# Altium schematic sheet D1.SchDoc(D1)
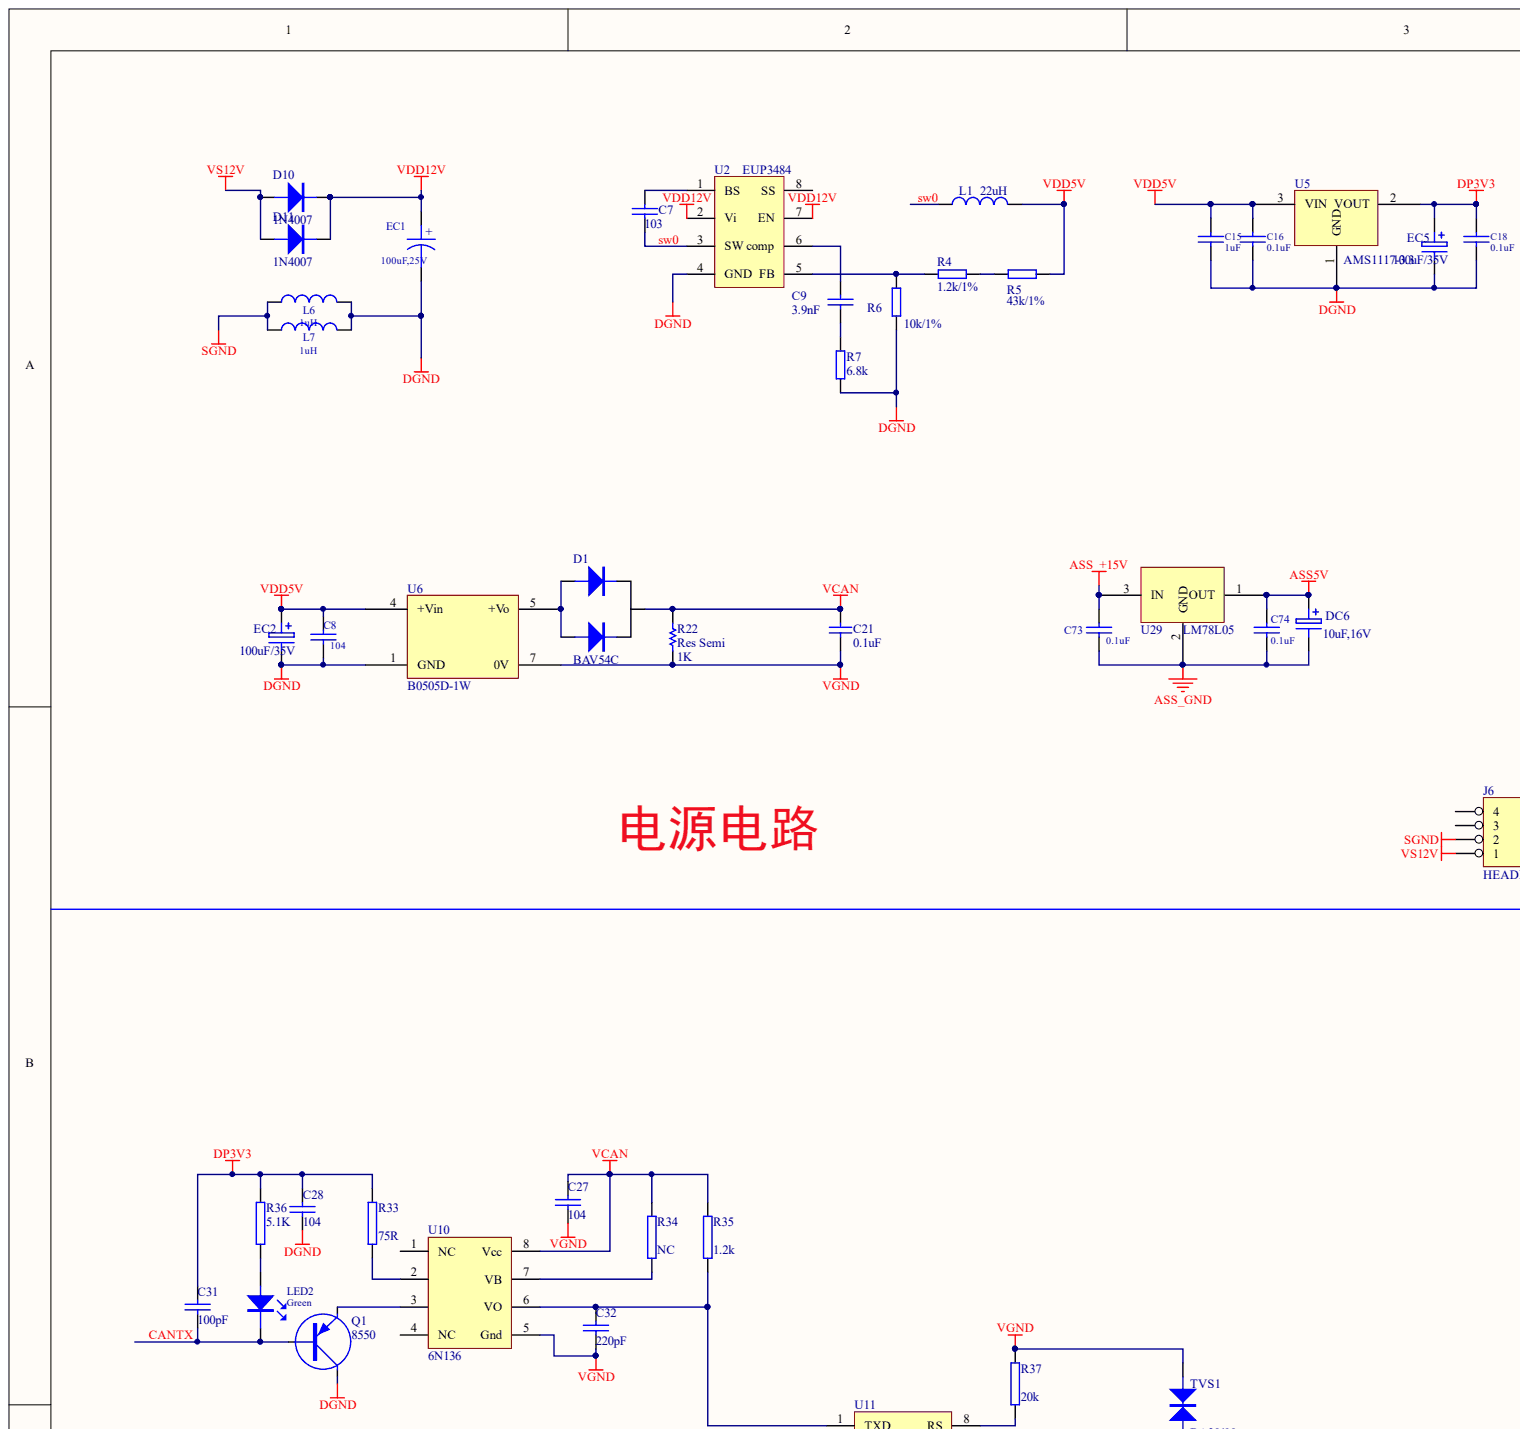
[diagram p0: D1.SchDoc, top left]
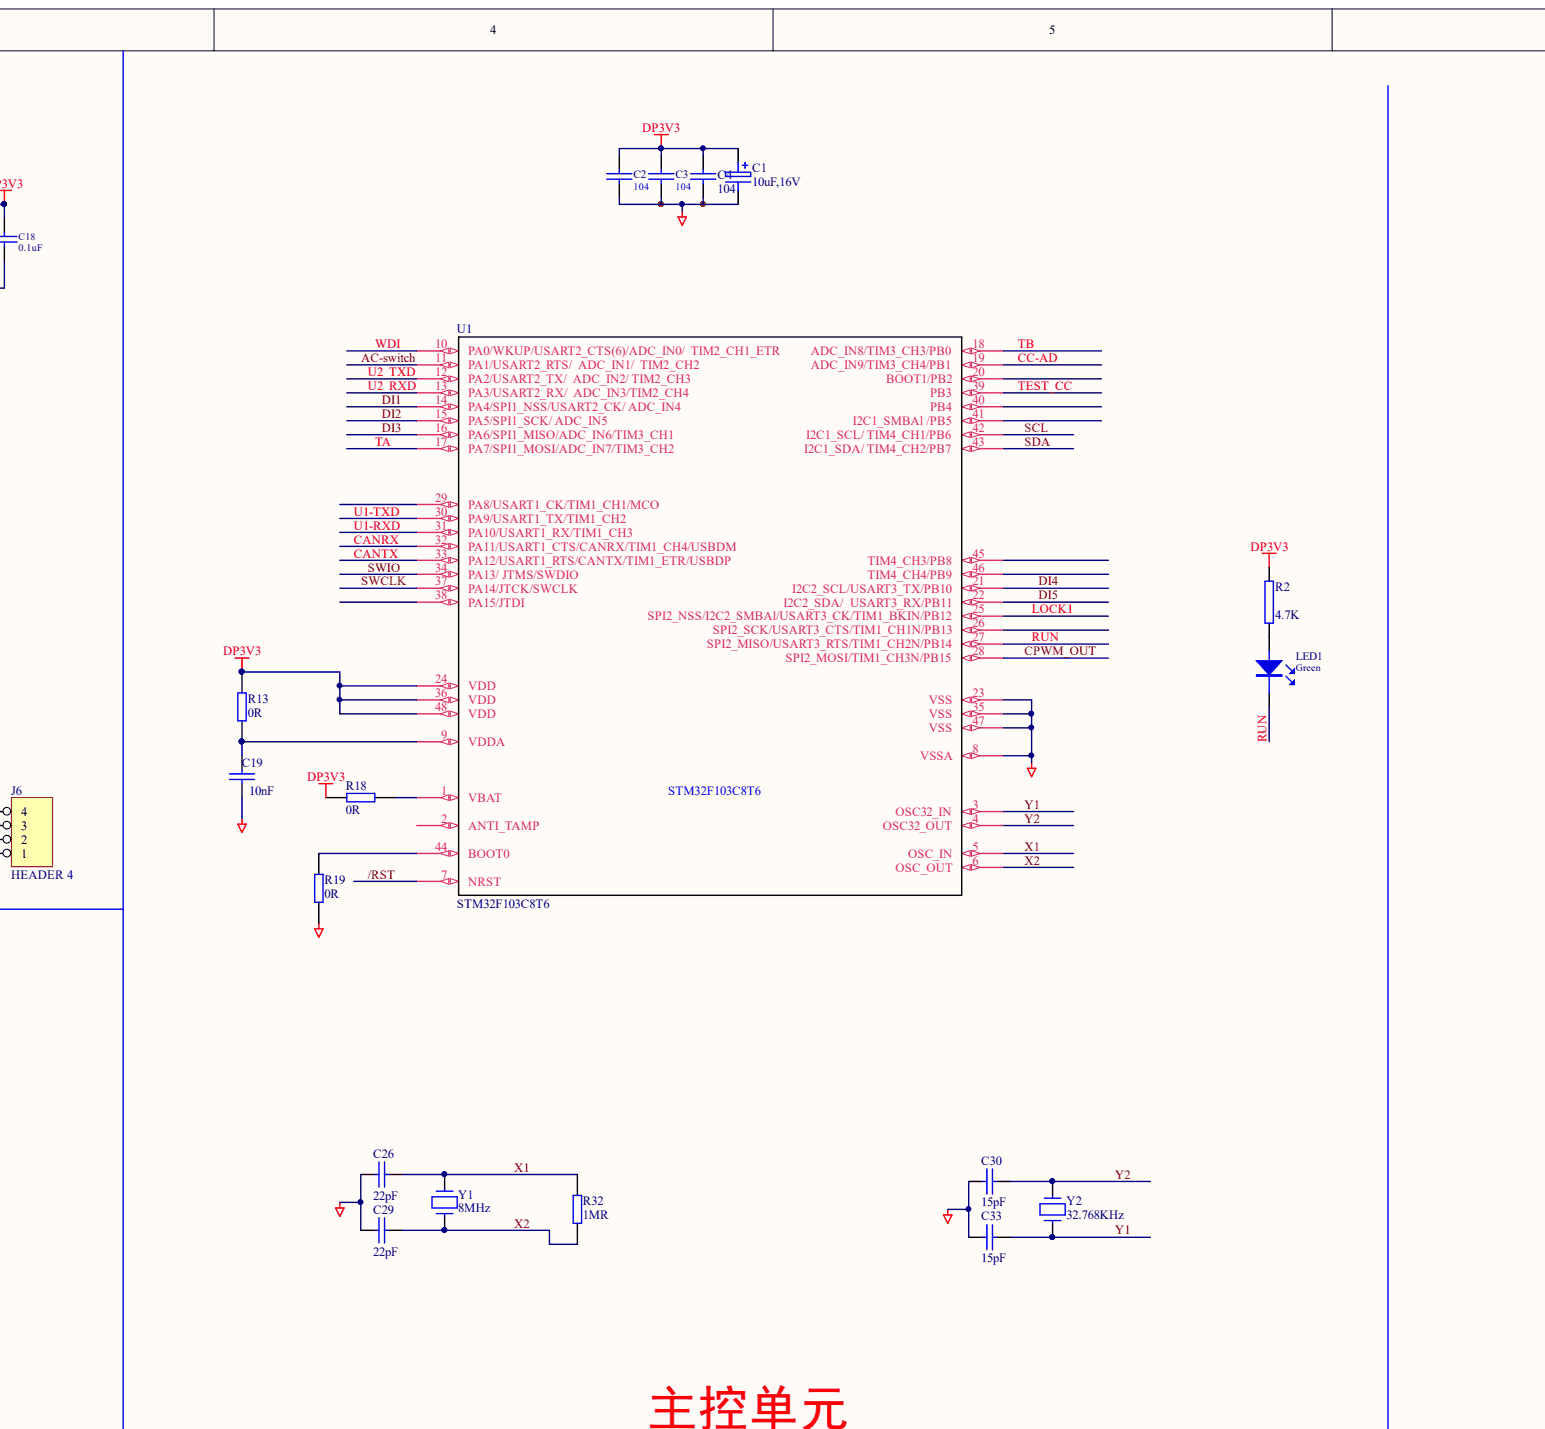
[diagram p1: D1.SchDoc, top centre]
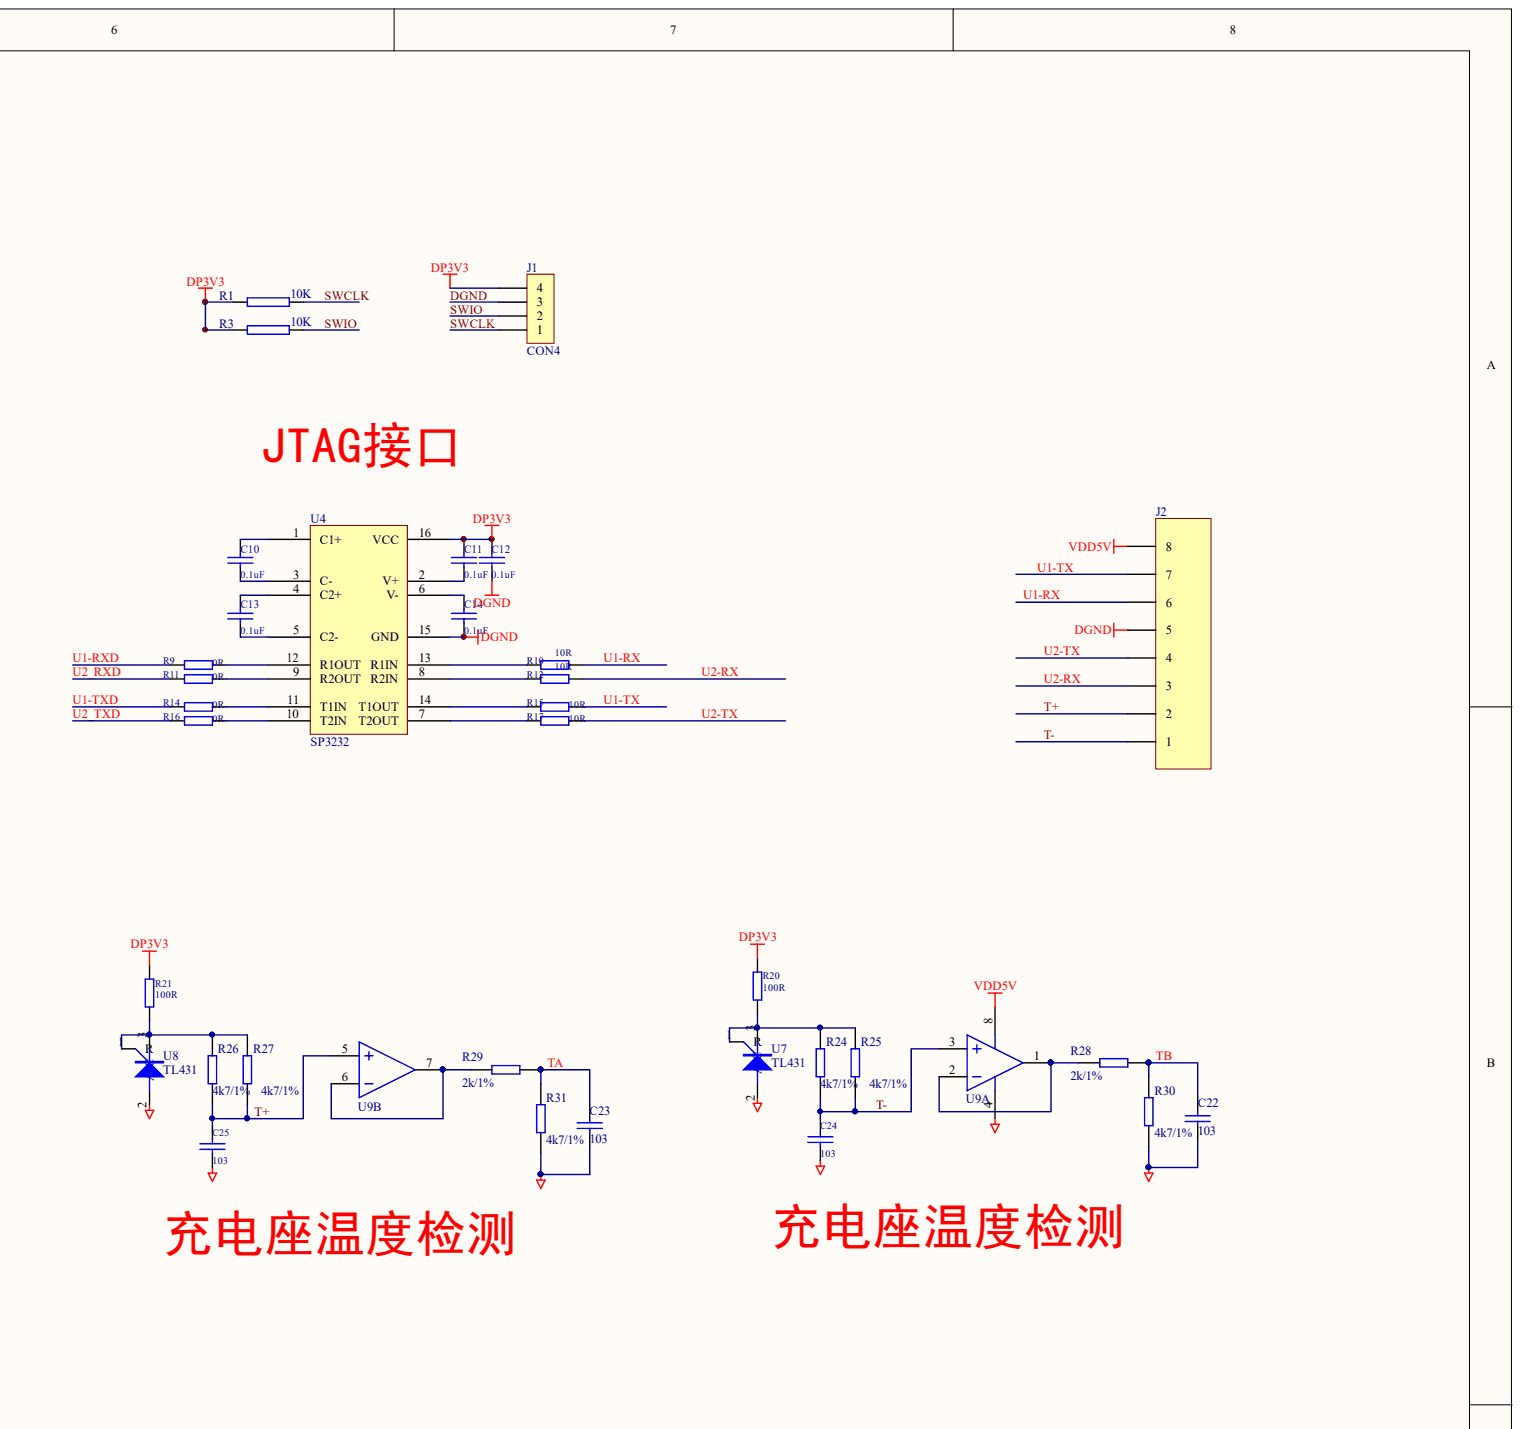
[diagram p2: D1.SchDoc, top right]
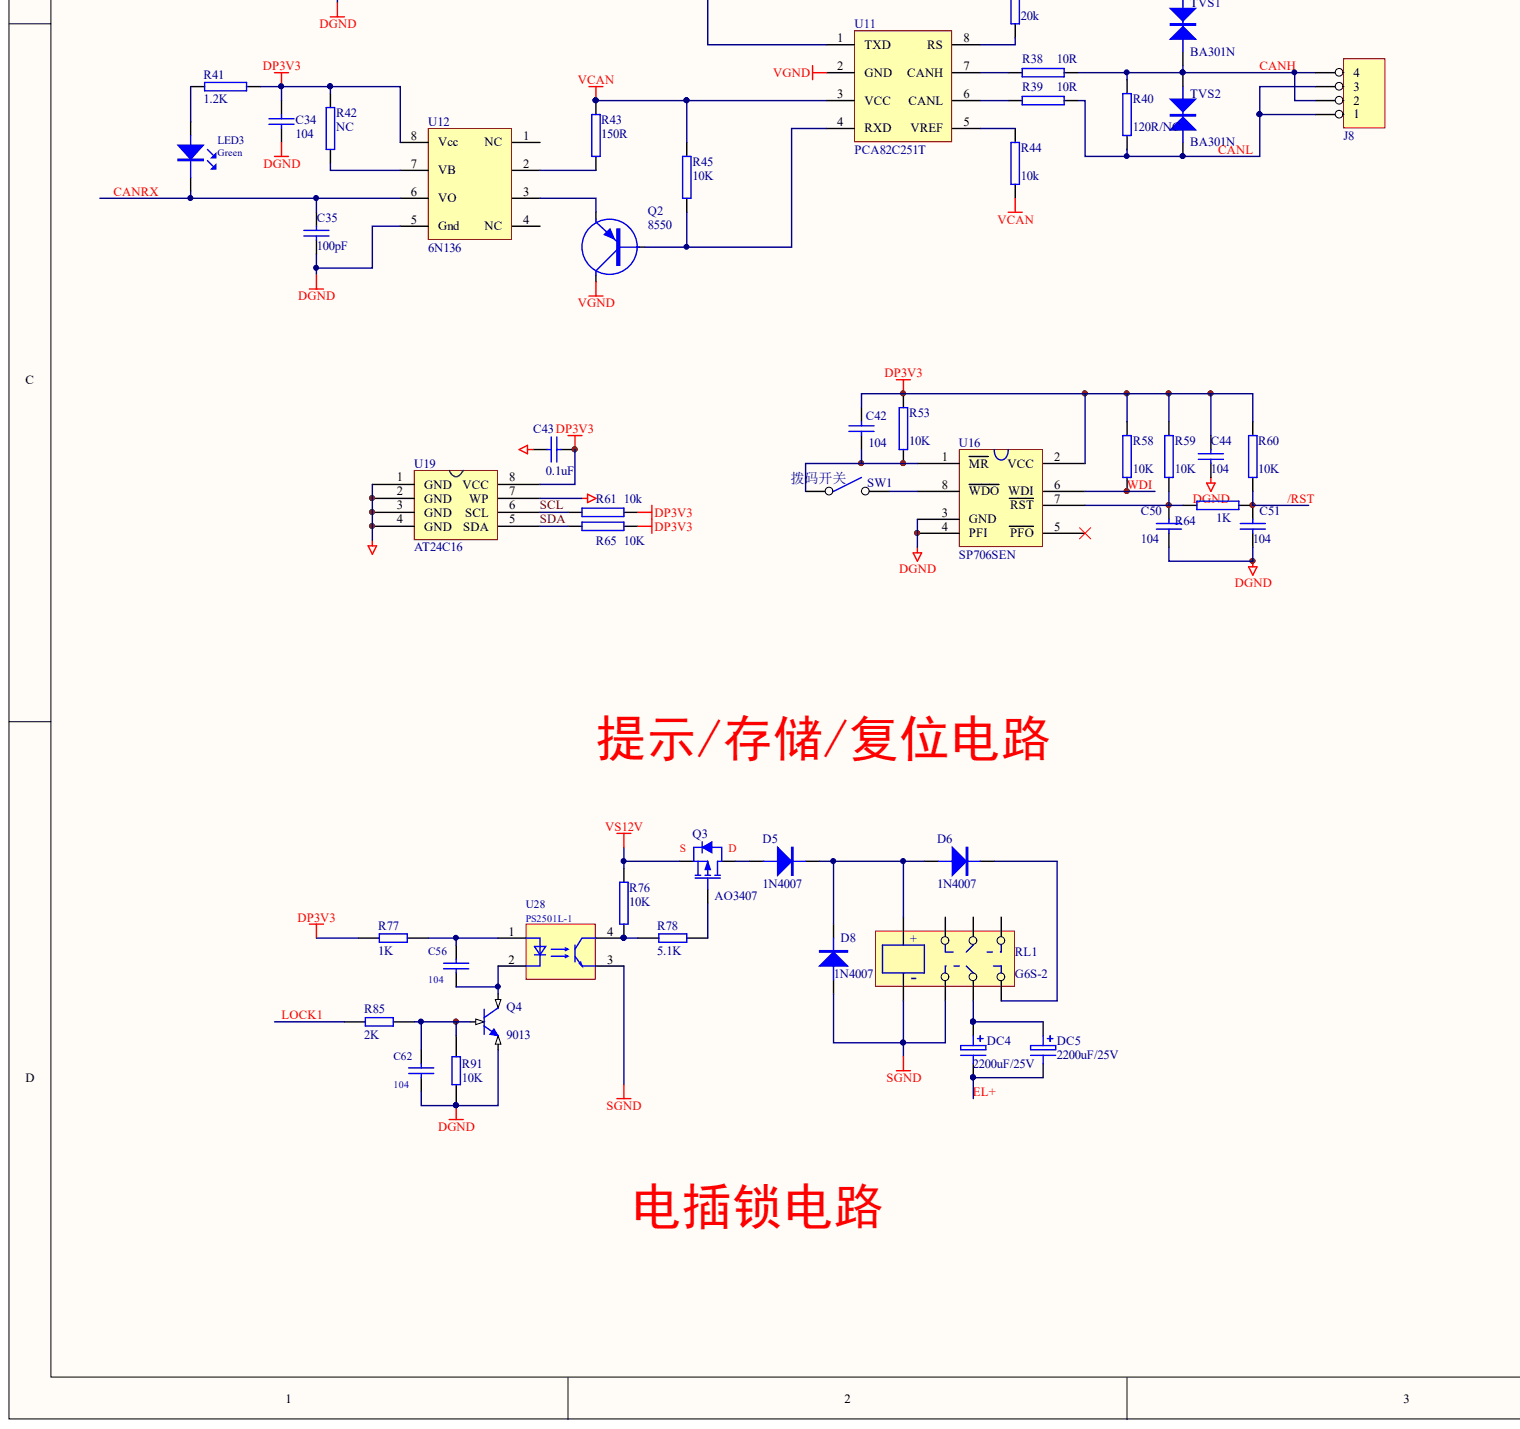
[diagram p3: D1.SchDoc, bottom left]
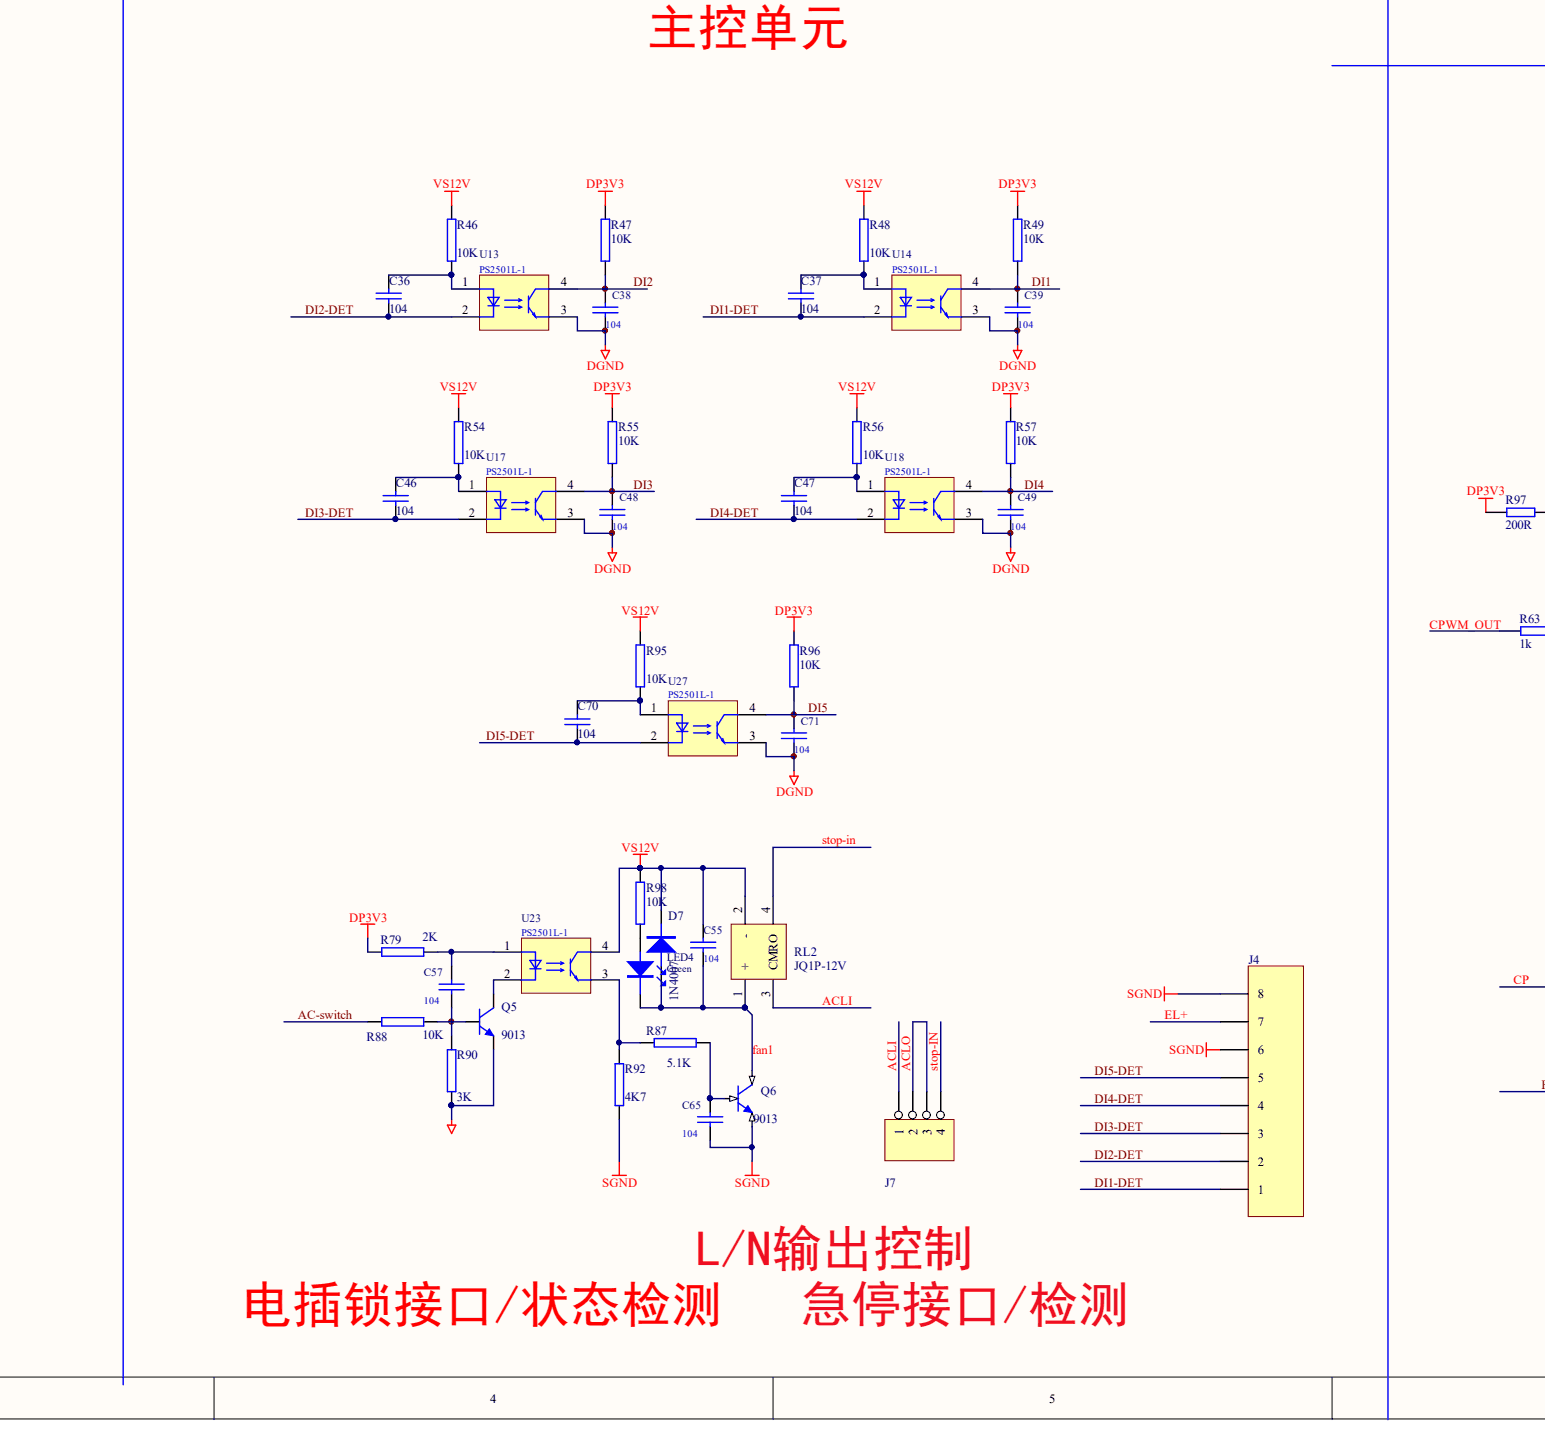
[diagram p4: D1.SchDoc, bottom centre]
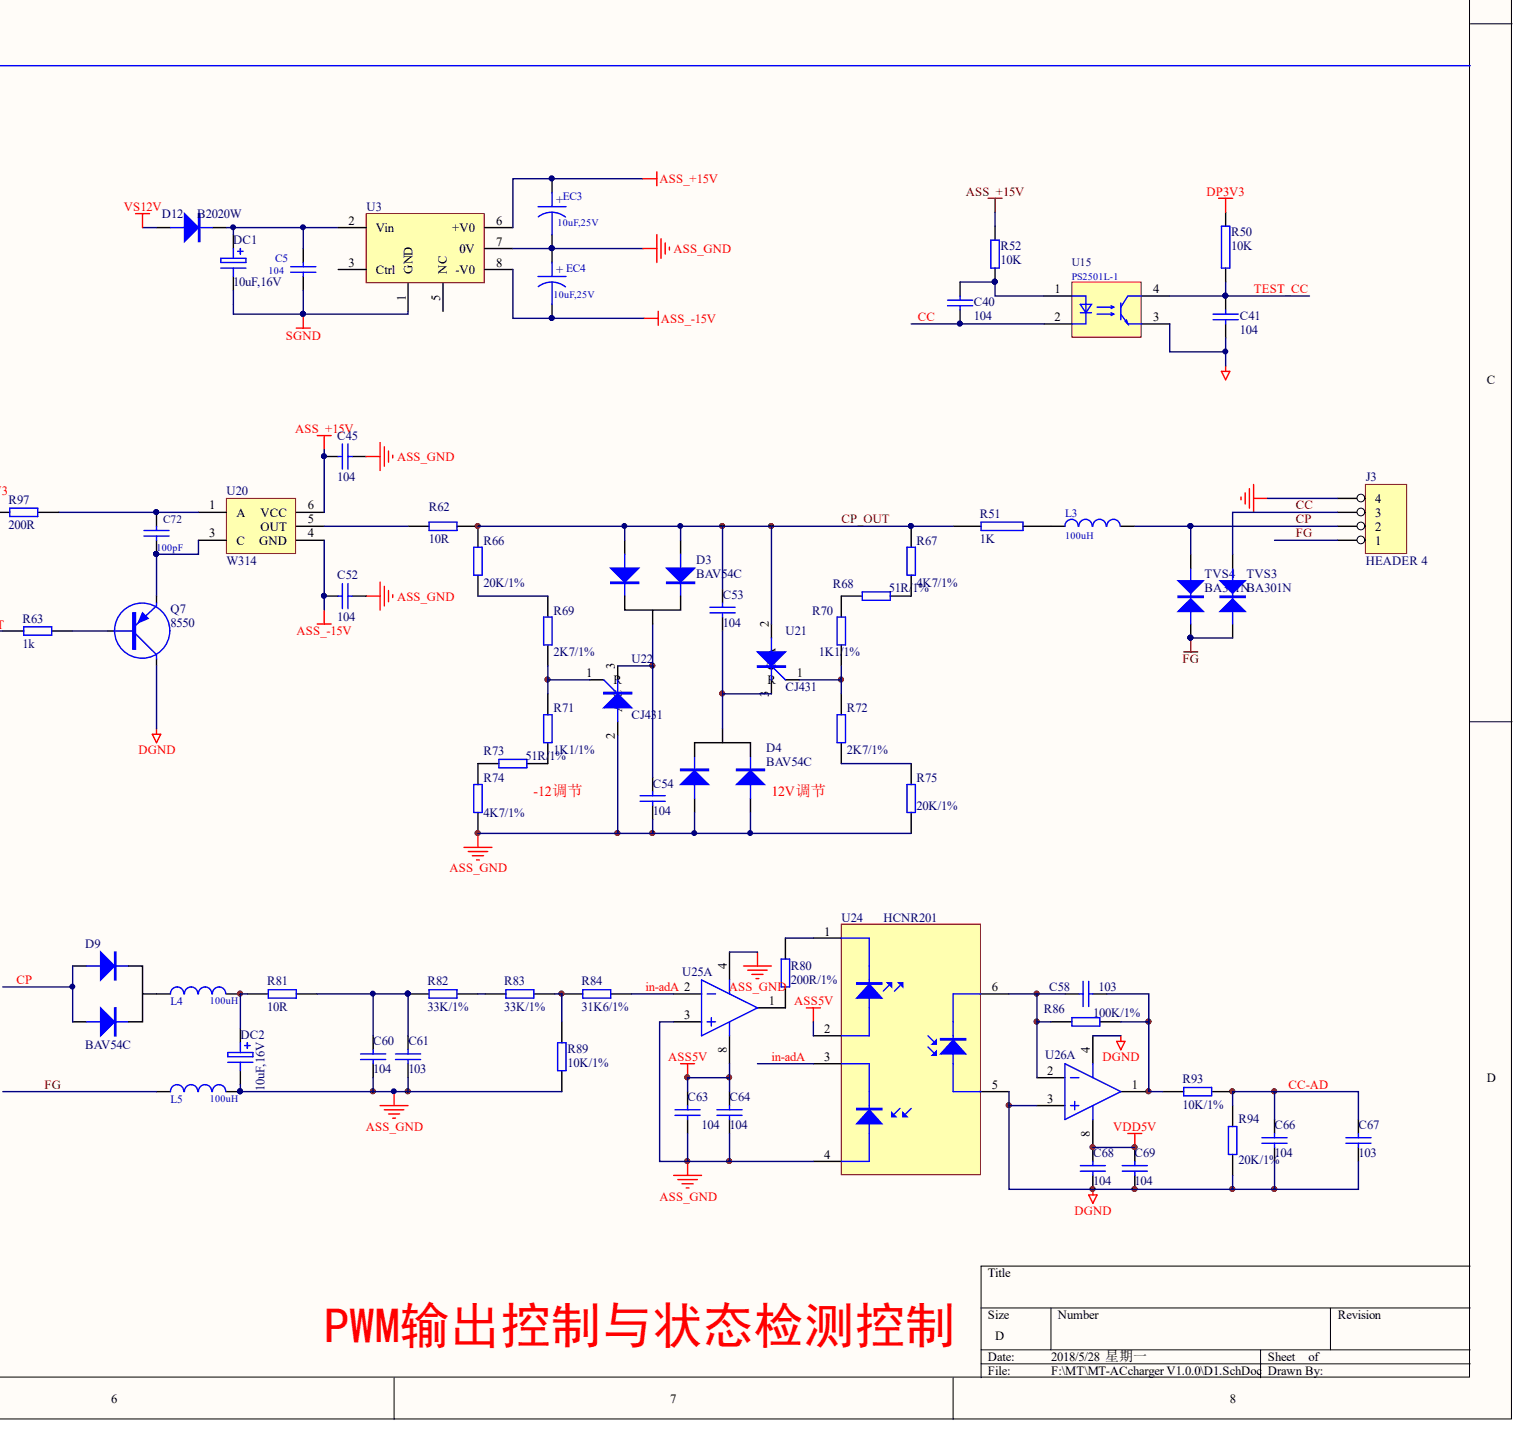
[diagram p5: D1.SchDoc, bottom right]

## Parts
C1  10uF,16V
C2  104
C3  104
C4  104
C5  104
C7  103
C8  104
C9  3.9nF
C10  0.1uF
C11  0.1uF
C12  0.1uF
C13  0.1uF
C14  0.1uF
C15  1uF
C16  0.1uF
C18  0.1uF
C19  10nF
C21  0.1uF
C22  103
C23  103
C24  103
C25  103
C26  22pF
C27  104
C28  104
C29  22pF
C30  15pF
C31  100pF
C32  220pF
C33  15pF
C34  104
C35  100pF
C36  104
C37  104
C38  104
C39  104
C40  104
C41  104
C42  104
C43  0.1uF
C44  104
C45  104
C46  104
C47  104
C48  104
C49  104
C50  104
C51  104
C52  104
C53  104
C54  104
C55  104
C56  104
C57  104
C58  103
C60  104
C61  103
C62  104
C63  104
C64  104
C65  104
C66  104
C67  103
C68  104
C69  104
C70  104
C71  104
C72  100pF
C73  0.1uF
C74  0.1uF
D1  BAV54C
D3  BAV54C
D4  BAV54C
D5  1N4007
D6  1N4007
D7  1N4007
D8  1N4007
D9  BAV54C
D10  1N4007
D11  1N4007
D12  B2020W
DC1  10uF,16V
DC2  10uF,16V
DC4  2200uF/25V
DC5  2200uF/25V
DC6  10uF,16V
EC1  100uF,25V
EC2  100uF/35V
EC3  10uF,25V
EC4  10uF,25V
EC5  100uF/35V
J1  CON4
J2  CON8  (value not drawn)
J3  HEADER 4
J4  CON8  (value not drawn)
J6  HEADER 4
J7  4 HEADER  (value not drawn)
J8  4 HEADER  (value not drawn)
L1  22uH
L3  100uH
L4  100uH
L5  100uH
L6  1uH
L7  1uH
LED1  Green
LED2  Green
LED3  Green
LED4  Green
Q1  8550
Q2  8550
Q3  AO3407
Q4  9013
Q5  9013
Q6  9013
Q7  8550
R1  10K
R2  4.7K
R3  10K
R4  1.2k/1%
R5  43k/1%
R6  10k/1%
R7  6.8k
R9  0R
R10  10R
R11  0R
R12  10R
R13  0R
R14  0R
R15  10R
R16  0R
R17  10R
R18  0R
R19  0R
R20  100R
R21  100R
R22  Res Semi  value 1K
R24  4k7/1%
R25  4k7/1%
R26  4k7/1%
R27  4k7/1%
R28  2k/1%
R29  2k/1%
R30  4k7/1%
R31  4k7/1%
R32  1MR
R33  75R
R34  NC
R35  1.2k
R36  5.1K
R37  20k
R38  10R
R39  10R
R40  120R/NC
R41  1.2K
R42  NC
R43  150R
R44  10k
R45  10K
R46  10K
R47  10K
R48  10K
R49  10K
R50  10K
R51  1K
R52  10K
R53  10K
R54  10K
R55  10K
R56  10K
R57  10K
R58  10K
R59  10K
R60  10K
R61  10K
R62  10R
R63  1k
R64  1K
R65  10k
R66  20K/1%
R67  4K7/1%
R68  51R/1%
R69  2K7/1%
R70  1K1/1%
R71  1K1/1%
R72  2K7/1%
R73  51R/1%
R74  4K7/1%
R75  20K/1%
R76  10K
R77  1K
R78  5.1K
R79  2K
R80  200R/1%
R81  10R
R82  33K/1%
R83  33K/1%
R84  31K6/1%
R85  2K
R86  100K/1%
R87  5.1K
R88  10K
R89  10K/1%
R90  3K
R91  10K
R92  4K7
R93  10K/1%
R94  20K/1%
R95  10K
R96  10K
R97  200R
R98  10K
RL1  G6S-2
RL2  JQ1P-12V
SW1  拨码开关
TVS1  BA301N
TVS2  BA301N
TVS3  BA301N
TVS4  BA301N
U1  STM32F103C8T6
U2  EUP3484
U3  WRA1215S-3WR2  (value not drawn)
U4  SP3232
U5  AMS1117-3.3
U6  B0505D-1W
U7  TL431
U8  TL431
U9  LM258  (value not drawn)  (parts A,B)
U10  6N136
U11  PCA82C251T
U12  6N136
U13  PS2501L-1
U14  PS2501L-1
U15  PS2501L-1
U16  SP706SEN
U17  PS2501L-1
U18  PS2501L-1
U19  AT24C16
U20  W314
U21  CJ431
U22  CJ431
U23  PS2501L-1
U24  HCNR201
U25  LM258  (value not drawn)  (part A)
U26  LM258  (value not drawn)  (part A)
U27  PS2501L-1
U28  PS2501L-1
U29  LM78L05
Y1  8MHz
Y2  32.768KHz

## Nets
ASS5V: C63.2 C64.1 C74.2 DC6.1 U24.2 U25.8 U29.1
ASS_+15V: C45.1 C73.2 EC3.1 R52.1 U3.6(+V0) U20.6(VCC) U29.3
ASS_-15V: C52.1 EC4.2 U3.8(-V0) U20.4(GND)
ASS_GND: C45.2 C52.2 C54.2 C60.2 C61.2 C63.1 C64.2 C73.1 C74.1 D4.1 D4.3 DC2.2 DC6.2 EC3.2 EC4.1 J3.4 L5.2 R74.1 R75.1 R89.1 U3.7(0V) U22.2 U24.4 U25.3 U25.4 U29.2
DP3V3: C1.1 C2.2 C3.2 C4.2 C11.2 C12.2 C18.1 C28.1 C31.1 C34.2 C42.1 C43.1 C44.1 EC5.1 J1.4 R1.2 R2.2 R3.2 R13.1 R18.2 R20.2 R21.2 R33.2 R36.2 R41.1 R42.1 R47.2 R49.2 R50.1 R53.2 R55.2 R57.2 R58.1 R59.1 R60.1 R61.1 R65.1 R77.1 R79.2 R96.2 R97.1 U1.24(VDD) U1.36(VDD) U1.48(VDD) U4.16(VCC) U5.2 U12.8(Vcc) U16.2(VCC) U19.8(VCC)
SGND: C5.1 C65.2 D8.1 DC1.2 J4.6 J4.8 J6.2 L6.1 L7.1 Q6.3 R92.2 RL1.3 RL1.12 U3.1(GND) U28.3
VCAN: C21.1 C27.1 D1.2 R22.2 R34.2 R35.2 R43.1 R44.1 R45.1 U10.8(Vcc) U11.3(VCC)
VDD5V: C8.2 C15.1 C16.1 C68.2 C69.2 EC2.1 J2.8 L1.2 R5.1 U5.3 U6.4(+Vin) U9.8 U26.8
VDD12V: D10.2 D11.2 EC1.1 U2.2(Vi) U2.7(EN)
VGND: C21.2 C27.2 C32.2 Q2.2 R22.1 R37.2 TVS1.2 U6.7(0V) U10.5(Gnd) U11.2(GND)
VS12V: C55.1 D7.2 D10.1 D11.1 D12.1 J6.1 Q3.3 R46.2 R48.2 R54.2 R56.2 R76.2 R95.2 R98.1 RL2.2(-) U23.4
/RST: C51.2 R60.2 R64.2 U1.7(NRST)
AC-SWITCH: R88.2 U1.11(PA1/USART2_RTS/)
ACLI: J7.1 RL2.3(CM)
ACLO: J7.2 J7.3
CANH: J8.2 J8.4 R38.2 R40.2 TVS1.1 TVS2.2
CANL: J8.1 J8.3 R39.2 R40.1 TVS2.1
CANRX: C35.1 LED3.2 U1.32(PA11/USART1_CTS/CANRX/TIM1_CH4/USBDM) U12.6(VO)
CANTX: C31.2 LED2.2 Q1.1 U1.33(PA12/USART1_RTS/CANTX/TIM1_ETR/USBDP)
CC: C40.2 J3.3 TVS3.2 U15.2
CC-AD: C66.2 C67.2 R93.2 R94.1 U1.19(ADC_IN9/TIM3_CH4/PB1)
CP: D9.1 D9.3 J3.2 L3.1 TVS4.2
CP_OUT: C53.2 D3.1 D3.3 R51.1 R62.1 R66.2 R67.2 U21.2
CPWM_OUT: R63.1 U1.28(SPI2_MOSI/TIM1_CH3N/PB15)
DGND: C1.2 C2.1 C3.1 C4.1 C8.1 C12.1 C14.1 C15.2 C16.2 C18.2 C19.2 C22.1 C23.1 C24.1 C25.1 C26.1 C28.2 C29.1 C30.1 C33.1 C34.1 C35.2 C38.2 C39.2 C41.2 C43.2 C44.2 C48.2 C49.2 C50.1 C51.1 C62.2 C66.1 C67.1 C68.1 C69.1 C71.2 EC1.2 EC2.2 EC5.2 J1.3 J2.5 L6.2 L7.2 Q1.2 Q4.3 Q5.3 Q7.2 R6.2 R7.2 R19.1 R30.1 R31.1 R90.2 R91.1 R94.2 U1.8(VSSA) U1.23(VSS) U1.35(VSS) U1.47(VSS) U2.4(GND) U4.15(GND) U5.1 U6.1(GND) U7.2 U8.2 U9.4 U12.5(Gnd) U13.3 U14.3 U15.3 U16.3(GND) U16.4(PFI) U17.3 U18.3 U19.1(GND) U19.2(GND) U19.3(GND) U19.4(GND) U19.7(WP) U24.5 U26.3 U26.4 U27.3
DI1: C39.1 R49.1 U1.14(PA4/SPI1_NSS/USART2_CK/_ADC_IN4) U14.4
DI1-DET: C37.1 J4.1 U14.2
DI2: C38.1 R47.1 U1.15(PA5/SPI1_SCK/_ADC_IN5) U13.4
DI2-DET: C36.1 J4.2 U13.2
DI3: C48.1 R55.1 U1.16(PA6/SPI1_MISO/ADC_IN6/TIM3_CH1) U17.4
DI3-DET: C46.1 J4.3 U17.2
DI4: C49.1 R57.1 U1.21(I2C2_SCL/USART3_TX/PB10) U18.4
DI4-DET: C47.1 J4.4 U18.2
DI5: C71.1 R96.1 U1.22(USART3_RX/PB11) U27.4
DI5-DET: C70.1 J4.5 U27.2
EL+: DC4.2 DC5.2 J4.7
FAN1: C55.2 D7.1 LED4.2 Q6.2 RL2.1(+)
FG: J3.1 L5.1 TVS3.1 TVS4.1
IN-ADA: R84.2 U24.3 U25.2
LOCK1: R85.1 U1.25(SPI2_NSS/I2C2_SMBAl/USART3_CK/TIM1_BKIN/PB12)
RUN: LED1.2 U1.27(SPI2_MISO/USART3_RTS/TIM1_CH2N/PB14)
SCL: R61.2 U1.42(I2C1_SCL/_TIM4_CH1/PB6) U19.6(SCL)
SDA: R65.2 U1.43(I2C1_SDA/_TIM4_CH2/PB7) U19.5(SDA)
STOP-IN: J7.4 RL2.4(RO)
SW0: C7.1 L1.1 U2.3(SW)
SWCLK: J1.1 R1.1 U1.37(PA14/JTCK/SWCLK)
SWIO: J1.2 R3.1 U1.34(PA13/_JTMS/SWDIO)
T+: C25.2 J2.2 R26.1 R27.1 U9.5
T-: C24.2 J2.1 R24.1 R25.1 U9.3
TA: C23.2 R29.1 R31.2 U1.17(PA7/SPI1_MOSI/ADC_IN7/TIM3_CH2)
TB: C22.2 R28.1 R30.2 U1.18(ADC_IN8/TIM3_CH3/PB0)
TEST_CC: C41.1 R50.2 U1.39(PB3) U15.4
U1-RX: J2.6 R10.2
U1-RXD: R9.1 U1.31(PA10/USART1_RX/TIM1_CH3)
U1-TX: J2.7 R15.2
U1-TXD: R14.1 U1.30(PA9/USART1_TX/TIM1_CH2)
U2-RX: J2.3 R12.2
U2-TX: J2.4 R17.2
U2_RXD: R11.1 U1.13(PA3/USART2_RX/)
U2_TXD: R16.1 U1.12(PA2/USART2_TX/)
WDI: R58.2 U1.10(PA0/WKUP/USART2_CTS(6)/ADC_IN0/) U16.6(WDI)
X1: C26.2 R32.1 U1.5(OSC_IN) Y1.2
X2: C29.2 R32.2 U1.6(OSC_OUT) Y1.1
Y1: C33.2 U1.3(OSC32_IN) Y2.1
Y2: C30.2 U1.4(OSC32_OUT) Y2.2
NetC5_2: C5.2 D12.2 DC1.1 U3.2(Vin)
NetC7_2: C7.2 U2.1(BS)
NetC9_1: C9.1 U2.6(comp)
NetC9_2: C9.2 R7.1
NetC10_1: C10.1 U4.3(C-)
NetC10_2: C10.2 U4.1(C1+)
NetC11_1: C11.1 U4.2(V+)
NetC13_1: C13.1 U4.5(C2-)
NetC13_2: C13.2 U4.4(C2+)
NetC14_2: C14.2 U4.6(V-)
NetC19_1: C19.1 R13.2 U1.9(VDDA)
NetC32_1: C32.1 R35.1 U10.6(VO) U11.1(TXD)
NetC36_2: C36.2 R46.1 U13.1
NetC37_2: C37.2 R48.1 U14.1
NetC40_1: C40.1 R52.2 U15.1
NetC42_2: C42.2 R53.1 SW1.1 U16.1(MR)
NetC46_2: C46.2 R54.1 U17.1
NetC47_2: C47.2 R56.1 U18.1
NetC50_2: C50.2 R59.2 R64.1 U16.7(RST)
NetC53_1: C53.1 D4.2 U21.3
NetC54_1: C54.1 D3.2 U22.3
NetC56_1: C56.1 R77.2 U28.1
NetC56_2: C56.2 Q4.2 U28.2
NetC57_1: C57.1 R79.1 U23.1
NetC57_2: C57.2 Q5.1 R88.1 R90.1
NetC58_1: C58.1 R86.1 R93.1 U26.1
NetC58_2: C58.2 R86.2 U24.6 U26.2
NetC60_1: C60.1 C61.1 R81.2 R82.1
NetC62_1: C62.1 Q4.1 R85.2 R91.2
NetC65_1: C65.1 Q6.1 R87.2
NetC70_2: C70.2 R95.1 U27.1
NetC72_1: C72.1 R97.2 U20.1(A)
NetC72_2: C72.2 Q7.3 U20.3(C)
NetD1_1: D1.1 D1.3 U6.5(+Vo)
NetD5_1: D5.1 Q3.2
NetD5_2: D5.2 D6.1 D8.2 RL1.1
NetD6_2: D6.2 RL1.5
NetD9_2: D9.2 L4.1
NetDC2_1: DC2.1 L4.2 R81.1
NetDC4_1: DC4.1 DC5.1 RL1.4
NetL3_2: L3.2 R51.2
NetLED1_1: LED1.1 R2.1
NetLED2_1: LED2.1 R36.1
NetLED3_1: LED3.1 R41.2
NetLED4_1: LED4.1 R98.2
NetQ1_3: Q1.3 U10.3
NetQ2_1: Q2.1 R45.2 U11.4(RXD)
NetQ2_3: Q2.3 U12.3
NetQ3_1: Q3.1 R78.2
NetQ5_2: Q5.2 U23.2
NetQ7_1: Q7.1 R63.2
NetR4_1: R4.1 R5.2
NetR4_2: R4.2 R6.1 U2.5(FB)
NetR9_2: R9.2 U4.12(R1OUT)
NetR10_1: R10.1 U4.13(R1IN)
NetR11_2: R11.2 U4.9(R2OUT)
NetR12_1: R12.1 U4.8(R2IN)
NetR14_2: R14.2 U4.11(T1IN)
NetR15_1: R15.1 U4.14(T1OUT)
NetR16_2: R16.2 U4.10(T2IN)
NetR17_1: R17.1 U4.7(T2OUT)
NetR18_1: R18.1 U1.1(VBAT)
NetR19_2: R19.2 U1.44(BOOT0)
NetR20_1: R20.1 R24.2 R25.2 U7.1 U7.3
NetR21_1: R21.1 R26.2 R27.2 U8.1 U8.3
NetR28_2: R28.2 U9.1 U9.2
NetR29_2: R29.2 U9.6 U9.7
NetR33_1: R33.1 U10.2
NetR34_1: R34.1 U10.7(VB)
NetR37_1: R37.1 U11.8(RS)
NetR38_1: R38.1 U11.7(CANH)
NetR39_1: R39.1 U11.6(CANL)
NetR42_2: R42.2 U12.7(VB)
NetR43_2: R43.2 U12.2
NetR44_2: R44.2 U11.5(VREF)
NetR62_2: R62.2 U20.5(OUT)
NetR66_1: R66.1 R69.2
NetR67_1: R67.1 R68.2
NetR68_1: R68.1 R70.2
NetR69_1: R69.1 R71.2 U22.1
NetR70_1: R70.1 R72.2 U21.1
NetR71_1: R71.1 R73.2
NetR72_1: R72.1 R75.2
NetR73_1: R73.1 R74.2
NetR76_1: R76.1 R78.1 U28.4
NetR80_1: R80.1 U25.1
NetR80_2: R80.2 U24.1
NetR82_2: R82.2 R83.1
NetR83_2: R83.2 R84.1 R89.2
NetR87_1: R87.1 R92.1 U23.3
NetSW1_2: SW1.2 U16.8(WDO)

Not connected: J6 3 4; RL1 8 9 10; U1 2(ANTI_TAMP) 20(BOOT1/PB2) 26(SPI2_SCK/USART3_CTS/TIM1_CH1N/PB13) 29(PA8/USART1_CK/TIM1_CH1/MCO) 38(PA15/JTDI) 40(PB4) 41(I2C1_SMBAl_/PB5) 45(TIM4_CH3/PB8) 46(TIM4_CH4/PB9); U2 8(SS); U3 3(Ctrl) 5(NC); U10 1(NC) 4(NC); U12 1(NC) 4(NC); U16 5(PFO)

## Library data of the parts
C21 = (no symbol name): Value: 105/25V; Published: 8-Jun-2000; LatestRevisionDate: 17-Jul-2002; LatestRevisionNote: Re-released for DXP Platform.; PackageReference: C1206; Publisher: Altium Limited
C1-C5 C7-C16 C18 C19 C22-C58 C60-C74 D1 D3-D12 DC1 DC2 DC4-DC6 EC1-EC5 J1-J4 J6-J8 L1 L3-L7 LED1-LED4 Q1-Q7 R1-R7 R9-R22 R24-R98 RL1 RL2 SW1 TVS1-TVS4 U1-U29 Y1 Y2 = (no symbol name)
  R22: Published: 8-Jun-2000; LatestRevisionDate: 17-Jul-2002; LatestRevisionNote: Re-released for DXP Platform.; PackageReference: AXIAL-0.5; Publisher: Altium Limited
